# Altium schematic sheet Comms.SchDoc
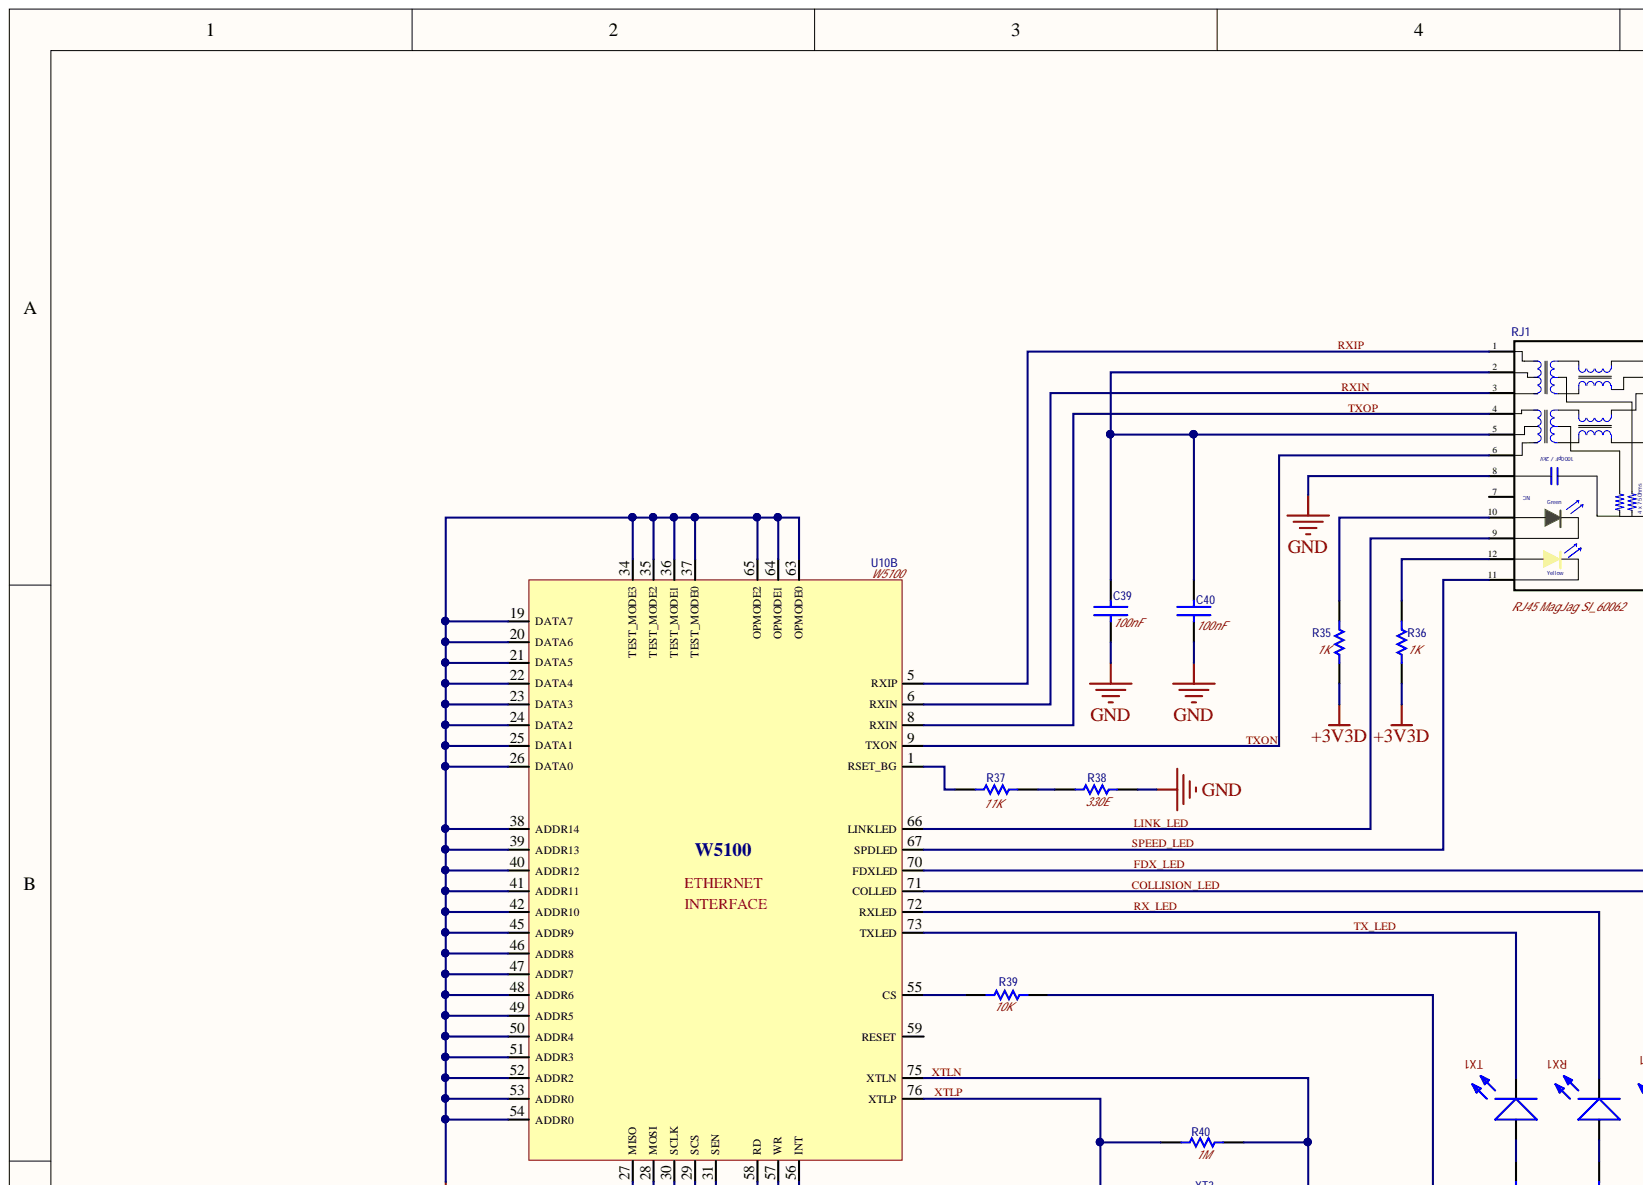
[diagram p0: Comms.SchDoc, top left]
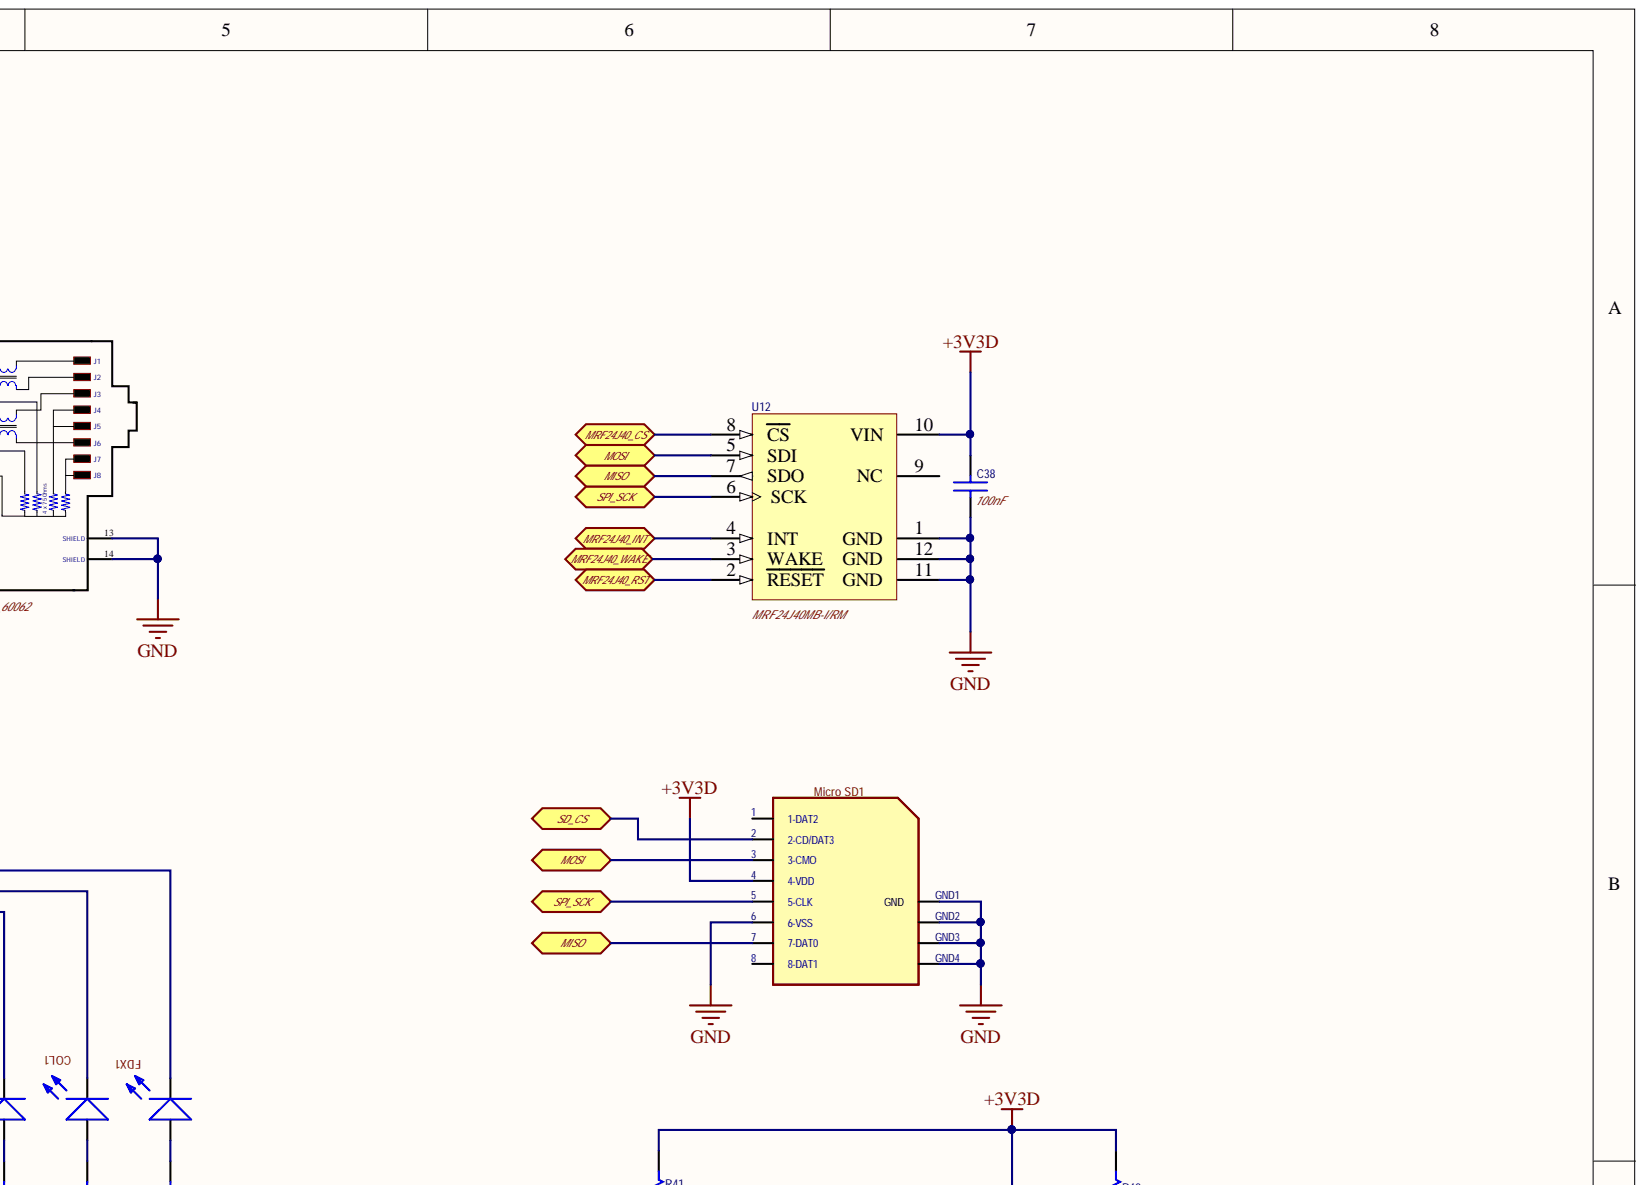
[diagram p1: Comms.SchDoc, top right]
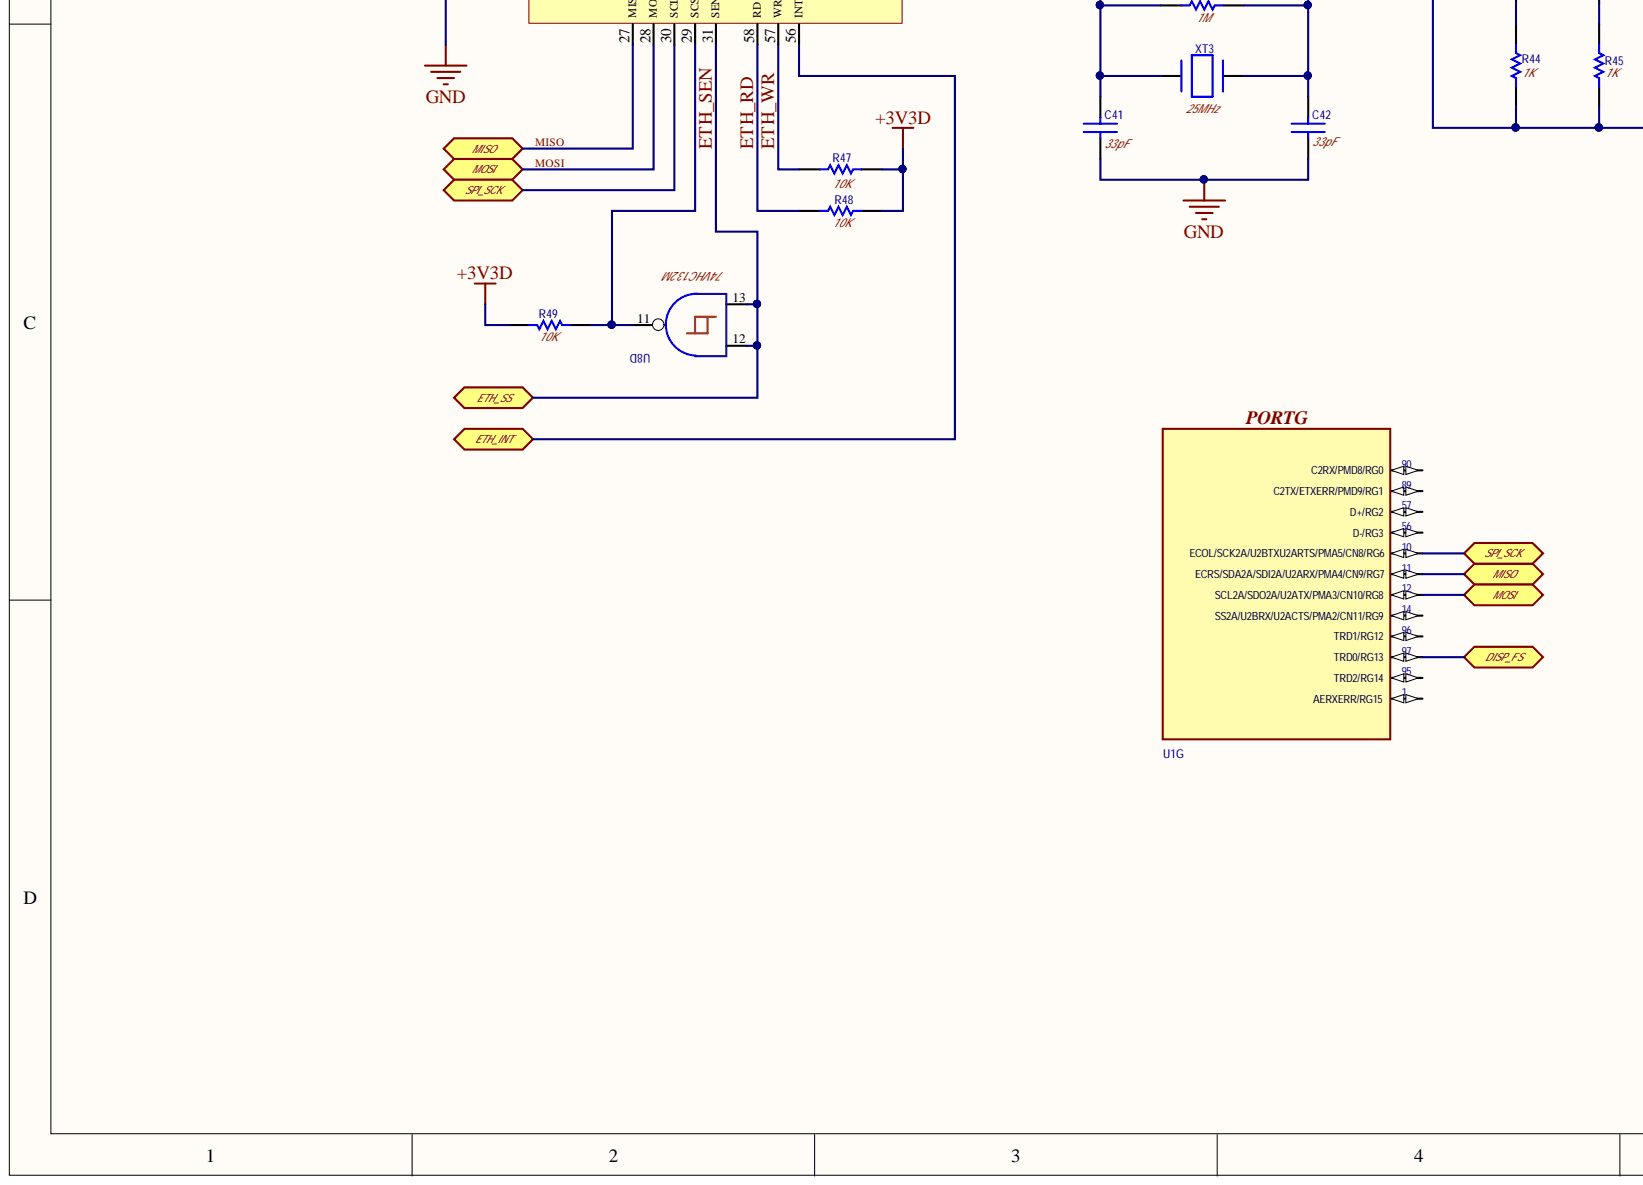
[diagram p2: Comms.SchDoc, bottom left]
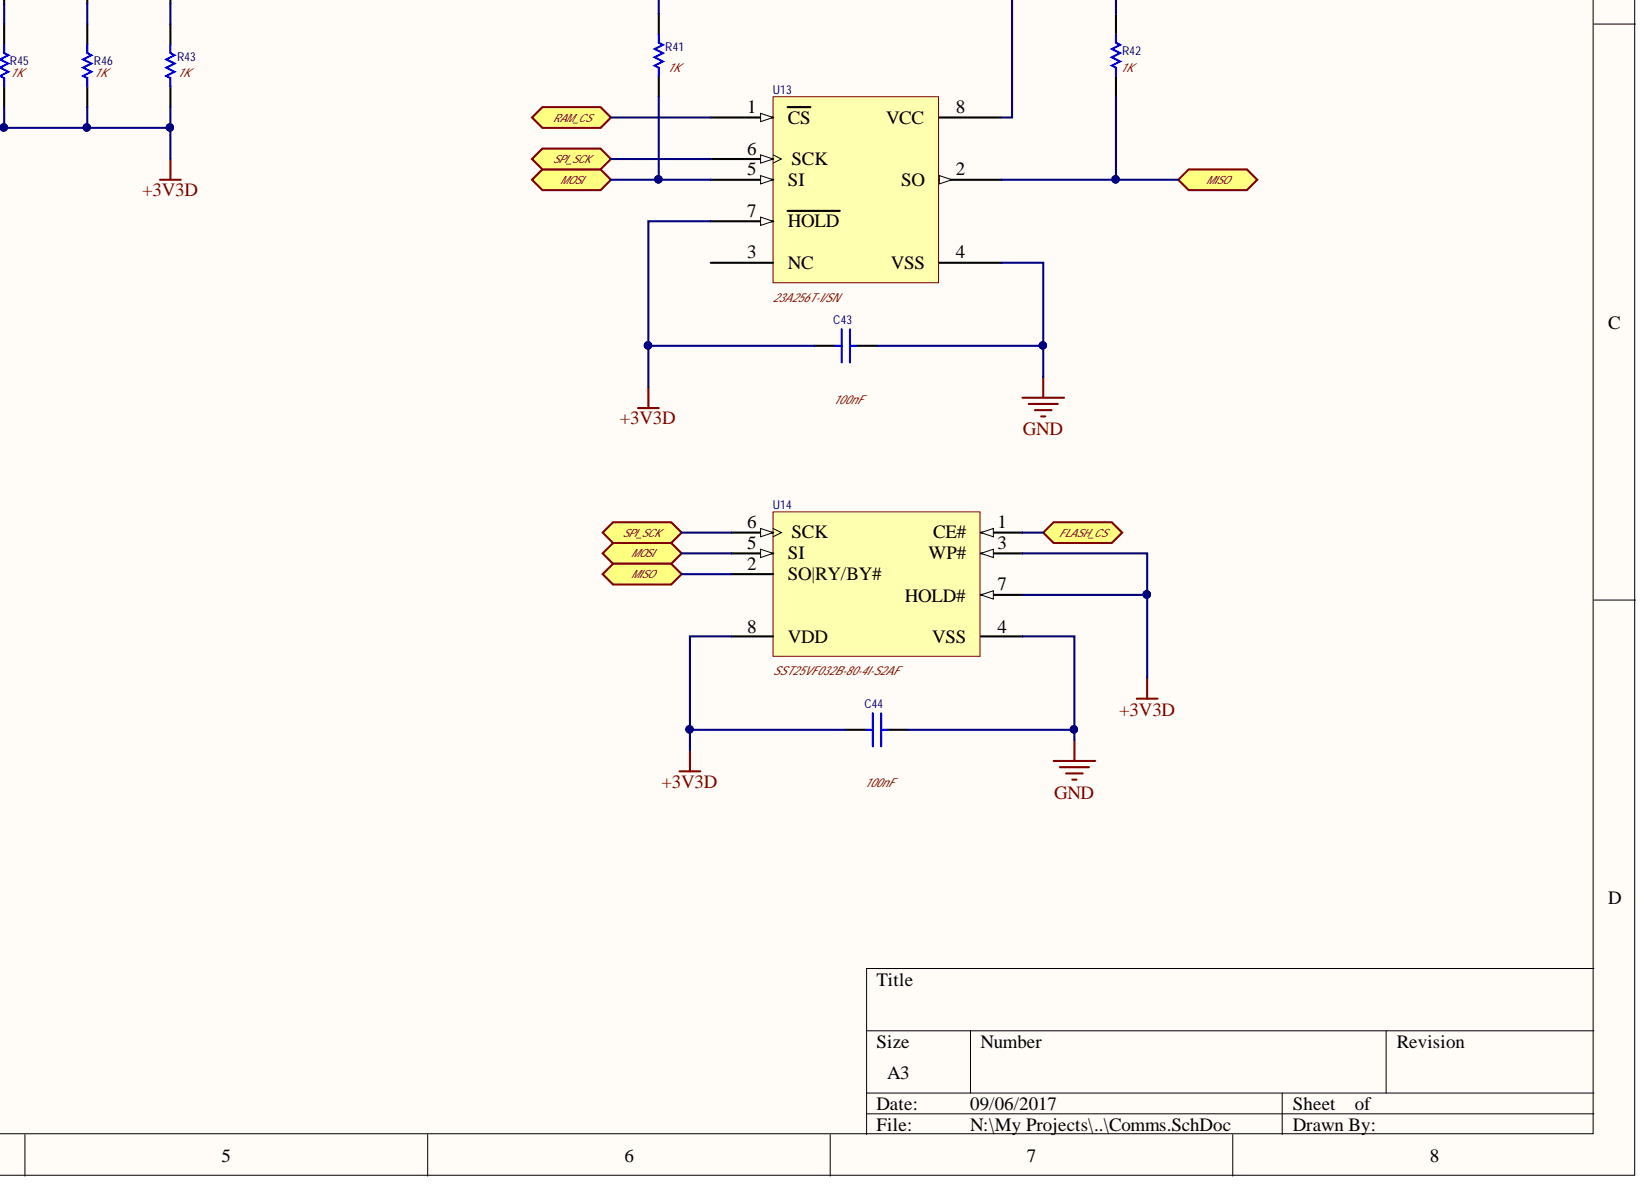
[diagram p3: Comms.SchDoc, bottom right]

## Parts
C38  value 100nF  [C - 0805]  (hidden comment: Cap Semi 0805)
C39  value 100nF  [C-0805]  (hidden comment: Cap 0805)
C40  value 100nF  [C-0805]  (hidden comment: Cap 0805)
C41  value 33pF  [C-0805]  (hidden comment: Cap 0805)
C42  value 33pF  [C-0805]  (hidden comment: Cap 0805)
C43  value 100nF  [C - 0805]  (hidden comment: Cap Semi 0805)
C44  value 100nF  [C - 0805]  (hidden comment: Cap Semi 0805)
COL1  LED-SMD_0805-RED  [LED3mm-Through Hole]  (value not drawn)
FDX1  LED-SMD_0805-GREEN  [LED-0805 - Red]  (value not drawn)
Micro_SD1  SDCARD  [SDCARD-15TW-8821]  (value not drawn)
R35  value 1K  [R-0805 - 1K]  (hidden comment: Resistor - SMD 0805)
R36  value 1K  [R-0805 - 1K]  (hidden comment: Resistor - SMD 0805)
R37  value 11K  [R-0805 - 12K]  (hidden comment: Resistor - SMD 0805)
R38  value 330E  [R-0805 - 330E]  (hidden comment: Resistor - SMD 0805)
R39  value 10K  [R-0805 - 10K]  (hidden comment: Resistor - SMD 0805)
R40  value 1M  [R-0805 - 1M]  (hidden comment: Resistor - SMD 0805)
R41  value 1K  [R-0805 - 1K]  (hidden comment: Resistor - SMD 0805)
R42  value 1K  [R-0805 - 1K]  (hidden comment: Resistor - SMD 0805)
R43  value 1K  [R-0805 - 1K]  (hidden comment: Resistor - SMD 0805)
R44  value 1K  [R-0805 - 1K]  (hidden comment: Resistor - SMD 0805)
R45  value 1K  [R-0805 - 1K]  (hidden comment: Resistor - SMD 0805)
R46  value 1K  [R-0805 - 1K]  (hidden comment: Resistor - SMD 0805)
R47  value 10K  [R-0805 - 10K]  (hidden comment: Resistor - SMD 0805)
R48  value 10K  [R-0805 - 10K]  (hidden comment: Resistor - SMD 0805)
R49  value 10K  [R-0805 - 10K]  (hidden comment: Resistor - SMD 0805)
RJ1  RJ45 MagJag SI_60062  [MagJag_SI_60062]
RX1  LED-SMD_0805-GREEN  [LED-0805 - Red]  (value not drawn)
TX1  LED-SMD_0805-GREEN  [LED-0805 - Red]  (value not drawn)
U1  PIC32MX795F512L-PT  [TQFP-PF100_N]  (value not drawn)  (part G)
U8  74VHC132M  [SO14]  (part D)
U10  W5100  [W5100]  (part B)
U12  MRF24J40MB-I/RM  [MRF24J40MB]
U13  23A256T-I/SN  [SOIC-SN8_N]
U14  SST25VF032B-80-4I-S2AF  [MCHP-SOIC-S2A8_N]
XT3  value 25MHz  [25MHz - RG]  (hidden comment: CRYSTAL-25MHz - Low Profile)

## Ports
DISP_FS, ETH_INT, ETH_SS, FLASH_CS, MISO, MOSI, MRF24J40_CS, MRF24J40_INT, MRF24J40_RST, MRF24J40_WAKE, RAM_CS, SD_CS, SPI_SCK

## Nets
+3V3D: C38.2 C43.2 C44.2 Micro_SD1.4(4-VDD) R35.1 R36.1 R39.2 R41.1 R42.1 R43.1 R44.1 R45.1 R46.1 R47.2 R48.2 R49.2 U12.10(VIN) U13.7(HOLD) U13.8(VCC) U14.3(WP#) U14.7(HOLD#) U14.8(VDD)
GND: C38.1 C39.1 C40.1 C41.1 C42.1 C43.1 C44.1 Micro_SD1.6(6-VSS) Micro_SD1.GND1(GND) Micro_SD1.GND2 Micro_SD1.GND3 Micro_SD1.GND4 R38.2 RJ1.8 RJ1.13(SHIELD) RJ1.14(SHIELD) U10.19(DATA7) U10.20(DATA6) U10.21(DATA5) U10.22(DATA4) U10.23(DATA3) U10.24(DATA2) U10.25(DATA1) U10.26(DATA0) U10.34(TEST_MODE3) U10.35(TEST_MODE2) U10.36(TEST_MODE1) U10.37(TEST_MODE0) U10.38(ADDR14) U10.39(ADDR13) U10.40(ADDR12) U10.41(ADDR11) U10.42(ADDR10) U10.45(ADDR9) U10.46(ADDR8) U10.47(ADDR7) U10.48(ADDR6) U10.49(ADDR5) U10.50(ADDR4) U10.51(ADDR3) U10.52(ADDR2) U10.53(ADDR0) U10.54(ADDR0) U10.63(OPMODE0) U10.64(OPMODE1) U10.65(OPMODE2) U12.1(GND) U12.11(GND) U12.12(GND) U13.4(VSS) U14.4(VSS)
COLLISION_LED: COL1.1 U10.71(COLLED)
DISP_FS: U1.97(TRD0/RG13) ; port DISP_FS
ETH_INT: U10.56(INT) ; port ETH_INT
ETH_RD: R48.1 U10.58(RD)
ETH_SEN: U8.12 U8.13 U10.31(SEN) ; port ETH_SS
ETH_WR: R47.1 U10.57(WR)
FDX_LED: FDX1.1 U10.70(FDXLED)
FLASH_CS: U14.1(CE#) ; port FLASH_CS
LINK_LED: RJ1.9 U10.66(LINKLED)
MISO: Micro_SD1.7(7-DAT0) R42.2 U1.11(ECRS/SDA2A/SDI2A/U2ARX/PMA4/CN9/RG7) U10.27(MISO) U12.7(SDO) U13.2(SO) U14.2(SO|RY/BY#) ; port MISO
MOSI: Micro_SD1.3(3-CMO) R41.2 U1.12(SCL2A/SDO2A/U2ATX/PMA3/CN10/RG8) U10.28(MOSI) U12.5(SDI) U13.5(SI) U14.5(SI) ; port MOSI
MRF24J40_CS: U12.8(CS) ; port MRF24J40_CS
MRF24J40_INT: U12.4(INT) ; port MRF24J40_INT
MRF24J40_RST: U12.2(RESET) ; port MRF24J40_RST
MRF24J40_WAKE: U12.3(WAKE) ; port MRF24J40_WAKE
RAM_CS: U13.1(CS) ; port RAM_CS
RX_LED: RX1.1 U10.72(RXLED)
RXIN: RJ1.3 U10.6(RXIN)
RXIP: RJ1.1 U10.5(RXIP)
SD_CS: Micro_SD1.2(2-CD/DAT3) ; port SD_CS
SPEED_LED: RJ1.11 U10.67(SPDLED)
SPI_SCK: Micro_SD1.5(5-CLK) U1.10(ECOL/SCK2A/U2BTXU2ARTS/PMA5/CN8/RG6) U10.30(SCLK) U12.6(SCK) U13.6(SCK) U14.6(SCK) ; port SPI_SCK
TX_LED: TX1.1 U10.73(TXLED)
TXON: RJ1.6 U10.9(TXON)
TXOP: RJ1.4 U10.8(RXIN)
XTLN: C42.2 R40.2 U10.75(XTLN) XT3.2
XTLP: C41.2 R40.1 U10.76(XTLP) XT3.1
NetC39_2: C39.2 C40.2 RJ1.2 RJ1.5
NetCOL1_2: COL1.2 R46.2
NetFDX1_2: FDX1.2 R43.2
NetR35_2: R35.2 RJ1.10
NetR36_2: R36.2 RJ1.12
NetR37_1: R37.1 U10.1(RSET_BG)
NetR37_2: R37.2 R38.1
NetR39_1: R39.1 U10.55(CS)
NetR44_2: R44.2 TX1.2
NetR45_2: R45.2 RX1.2
NetR49_1: R49.1 U8.11 U10.29(SCS)

Not connected: Micro_SD1 1(1-DAT2) 8(8-DAT1); RJ1 7(NC); U1 1(AERXERR/RG15) 14(SS2A/U2BRX/U2ACTS/PMA2/CN11/RG9) 56(D-/RG3) 57(D+/RG2) 89(C2TX/ETXERR/PMD9/RG1) 90(C2RX/PMD8/RG0) 95(TRD2/RG14) 96(TRD1/RG12); U10 59(RESET); U12 9(NC); U13 3(NC)

## Library data of the parts
C38 C43 C44 = Cap 0603: Capacitor SMD; Component Kind: Standard; LatestRevisionDate: 17-Jul-2002; LatestRevisionNote: Re-released for DXP Platform.; PackageReference: C 0805; Published: 8-Jun-2000; Library Name: MKM Capacitors.IntLib; Publisher: Altium Limited
C39-C42 = Cap 0805: Capacitor SMD; Component Kind: Standard; LatestRevisionDate: 17-Jul-2002; LatestRevisionNote: Re-released for DXP Platform.; PackageReference: C0805; Published: 8-Jun-2000; Library Name: MKM Capacitors.IntLib; Publisher: Altium Limited
COL1 = LED-SMD_0805-RED: LED 0805 Red; Assy: Loaded; CH: WL-08-2004; CR: VV; Vendor: Fairchild; Vendor P/N: QTLP610C-AG; ComponentHeight: 1.10mm; Component Kind: Standard; Library Name: MKM Optoelectronics.IntLib
FDX1 RX1 TX1 = LED-SMD_0805-GREEN: LED SMD 0805-GREEN; Assy: Loaded; CH: WL-08-2004; CR: VV; Vendor: Fairchild; Vendor P/N: QTLP610C-AG; ComponentHeight: 1.10mm; Component Kind: Standard; Library Name: MKM Optoelectronics.IntLib
Micro_SD1 = SDCARD: SD Card SDCARD 15TW 8821; Component Kind: Standard; Library Name: MKM Connectors.IntLib
R35 R36 R41-R46 = R0805- 1K: Component Kind: Standard; Description: Resistor - SMD 0805; LatestRevisionDate: 17-Jul-2002; LatestRevisionNote: Re-released for DXP Platform.; PackageReference: AXIAL-0.3; Published: 8-Jun-2000; Signal Integrity: Res; Simulation: RESISTOR; Library Name: MKM Resistors.IntLib; Publisher: Altium Limited
R37 = R0805- 12K_1%: Component Kind: Standard; Description: Resistor - SMD 0805; LatestRevisionDate: 17-Jul-2002; LatestRevisionNote: Re-released for DXP Platform.; PackageReference: AXIAL-0.3; Published: 8-Jun-2000; Signal Integrity: Res; Simulation: RESISTOR; Library Name: MKM Resistors.IntLib; Publisher: Altium Limited
R38 = R0805- 330E: Component Kind: Standard; Description: Resistor - SMD 0805; LatestRevisionDate: 17-Jul-2002; LatestRevisionNote: Re-released for DXP Platform.; PackageReference: AXIAL-0.3; Published: 8-Jun-2000; Signal Integrity: Res; Simulation: RESISTOR; Library Name: MKM Resistors.IntLib; Publisher: Altium Limited
R39 R47-R49 = R0805- 10K: Component Kind: Standard; Description: Resistor - SMD 0805; LatestRevisionDate: 17-Jul-2002; LatestRevisionNote: Re-released for DXP Platform.; PackageReference: AXIAL-0.3; Published: 8-Jun-2000; Signal Integrity: Res; Simulation: RESISTOR; Library Name: MKM Resistors.IntLib; Publisher: Altium Limited
R40 = R0805- 1M: Component Kind: Standard; Description: Resistor - SMD 0805; LatestRevisionDate: 17-Jul-2002; LatestRevisionNote: Re-released for DXP Platform.; PackageReference: AXIAL-0.3; Published: 8-Jun-2000; Signal Integrity: Res; Simulation: RESISTOR; Library Name: MKM Resistors.IntLib; Publisher: Altium Limited
U1 = PIC32MX7X5F*L-PT: High Performance, USB, CAN and Ethernet 32-Bit Flash Microcontroller; >NAME: IC2; Component Kind: Standard; Library Name: MKM ICs.IntLib
U8 = 74VHC132M: QUAD 2-Input NAND Schmitt Trigger; Assy: Loaded; CH: WL-07-2004; CR: WL; Vendor: ST; ComponentHeight: 1.75mm; Component Kind: Standard; VendorP/N: 74VHC132M; Library Name: MKM ICs.IntLib
U12 = MRF24J40MB-I/RM: 2.4 GHz IEEE Std. 802.15.4, 20 dBm RF Transceiver 12-Pin Module, Industrial Temperature; Component Kind: Standard; ComponentLink1Description: Datasheet; ComponentLink1URL: http://ww1.microchip.com/downloads/en/DeviceDoc/70599B.pdf; ComponentLink2Description: Packaging Specification; ComponentLink2URL: http://www.microchip.com/stellent/groups/techpub_sg/documents/packagingspec/en012702.pdf; ComponentLink3Description: Microchip.com Home; ComponentLink3URL: http://www.microchip.com/; DatasheetVersion: revB, Aug-2009; PackageDescription: Transceiver Module; SM; 12 Leads; Body 1.3 x 0.9 x 0.1" (LxWxH), 0.1" Pitch; PackageReference: MRF24J40MB; PackageVersion: Aug-2009; Published: 2-Dec-2009; Supplier 1: Digi-Key; Supplier 2: Farnell; Supplier 3: Farnell; Supplier Part Number 1: MRF24J40MB-I/RM-ND; Supplier Part Number 2: 175519901; Supplier Part Number 3: 1755199; Library Name: MKM Wireless.IntLib; Publisher: Altium Limited
U13 = 23A256T-I/SN: 256K, 32768x8-bit, 1.8V SPI Serial SRAM, 8-Pin SOIC 3.9mm, Industrial TemperatureTape and Reel; Manufacturer: Microchip; Code_IPC: SOIC127P600-8; Code_JEDEC: MS-012; Component Kind: Standard; ComponentLink1Description: Manufacturer URL; ComponentLink1URL: http://www.microchip.com/; ComponentLink2Description: Datasheet; ComponentLink2URL: http://ww1.microchip.com/downloads/en/DeviceDoc/22100D.pdf; ComponentLink3Description: Package Specification; ComponentLink3URL: http://www.microchip.com/stellent/groups/techpub_sg/documents/packagingspec/en012702.pdf; DatasheetVersion: revD, Apr-2009; Mounting Technology: Surface Mount; PackageDescription: 8-Lead Plastic Small Outline (SN) - Narrow, 3.90mm Body [SOIC]; PackageReference: SOIC-SN8; PackageVersion: revBB, Aug-2009; PartNumber: 23A256T-I/SN; Supplier 1: Digi-Key; Supplier 2: Mouser; Supplier Part Number 1: 23A256T-I/SN-ND; Supplier Part Number 2: 579-23A256T-I/SN; Library Name: MKM ICs.IntLib
U14 = SST25VF032B-80-4I-S2AF: 32 Mbit SPI Serial Flash, 2.7 to 3.6 V, 80 MHz, -40 to 85 degC, 8-pin SOIC (S2A8), Tube; Manufacturer: Microchip; Component Kind: Standard; ComponentLink1Description: Manufacturer URL; ComponentLink1URL: http://www.microchip.com; ComponentLink2Description: Datasheet; ComponentLink2URL: http://ww1.microchip.com/downloads/en/DeviceDoc/25071A.pdf; ComponentLink3Description: Package Specification; ComponentLink3URL: http://ww1.microchip.com/downloads/en/DeviceDoc/25051A.pdf; ComponentLink4Description: Product URL; ComponentLink4URL: http://www.microchip.com/SST25VF032B; DatasheetVersion: Rev. A; Mounting Technology: Surface Mount; PackageDescription: 8-Lead Plastic Small Outline Intergrated Circuit, 5.275 x 5.275 mm Body, 1.27 mm Pitch; PackageReference: SOIC-S2A8; PackageVersion: Rev. A; PartNumber: SST25VF032B-80-4I-S2AF; Supplier 1: Digi-Key; Supplier 2: Farnell; Supplier 3: Farnell; Supplier 4: Newark; Supplier 5: Mouser; Supplier Part Number 1: SST25VF032B-80-4I-S2AF-ND; Supplier Part Number 2: 1829955; Supplier Part Number 3: 1871811; Supplier Part Number 4: 92R4559; Supplier Part Number 5: 804-25VF032B8IS2AF; Library Name: MKM ICs.IntLib
XT3 = CRYSTAL-25MHz - Low Profile: Crystal Vert Metal Can (11x5mm); CH: NN-02-2002; CR: NN; Component Kind: Standard; Library Name: MKM Miscealenious devices.IntLib
RJ1 = RJ45 MagJag SI_60062: Component Kind: Standard; Library Name: MKM Connectors.IntLib
U10 = W5100: Component Kind: Standard; Library Name: MKM ICs.IntLib
